# Altium schematic sheet int_driver.SchDoc
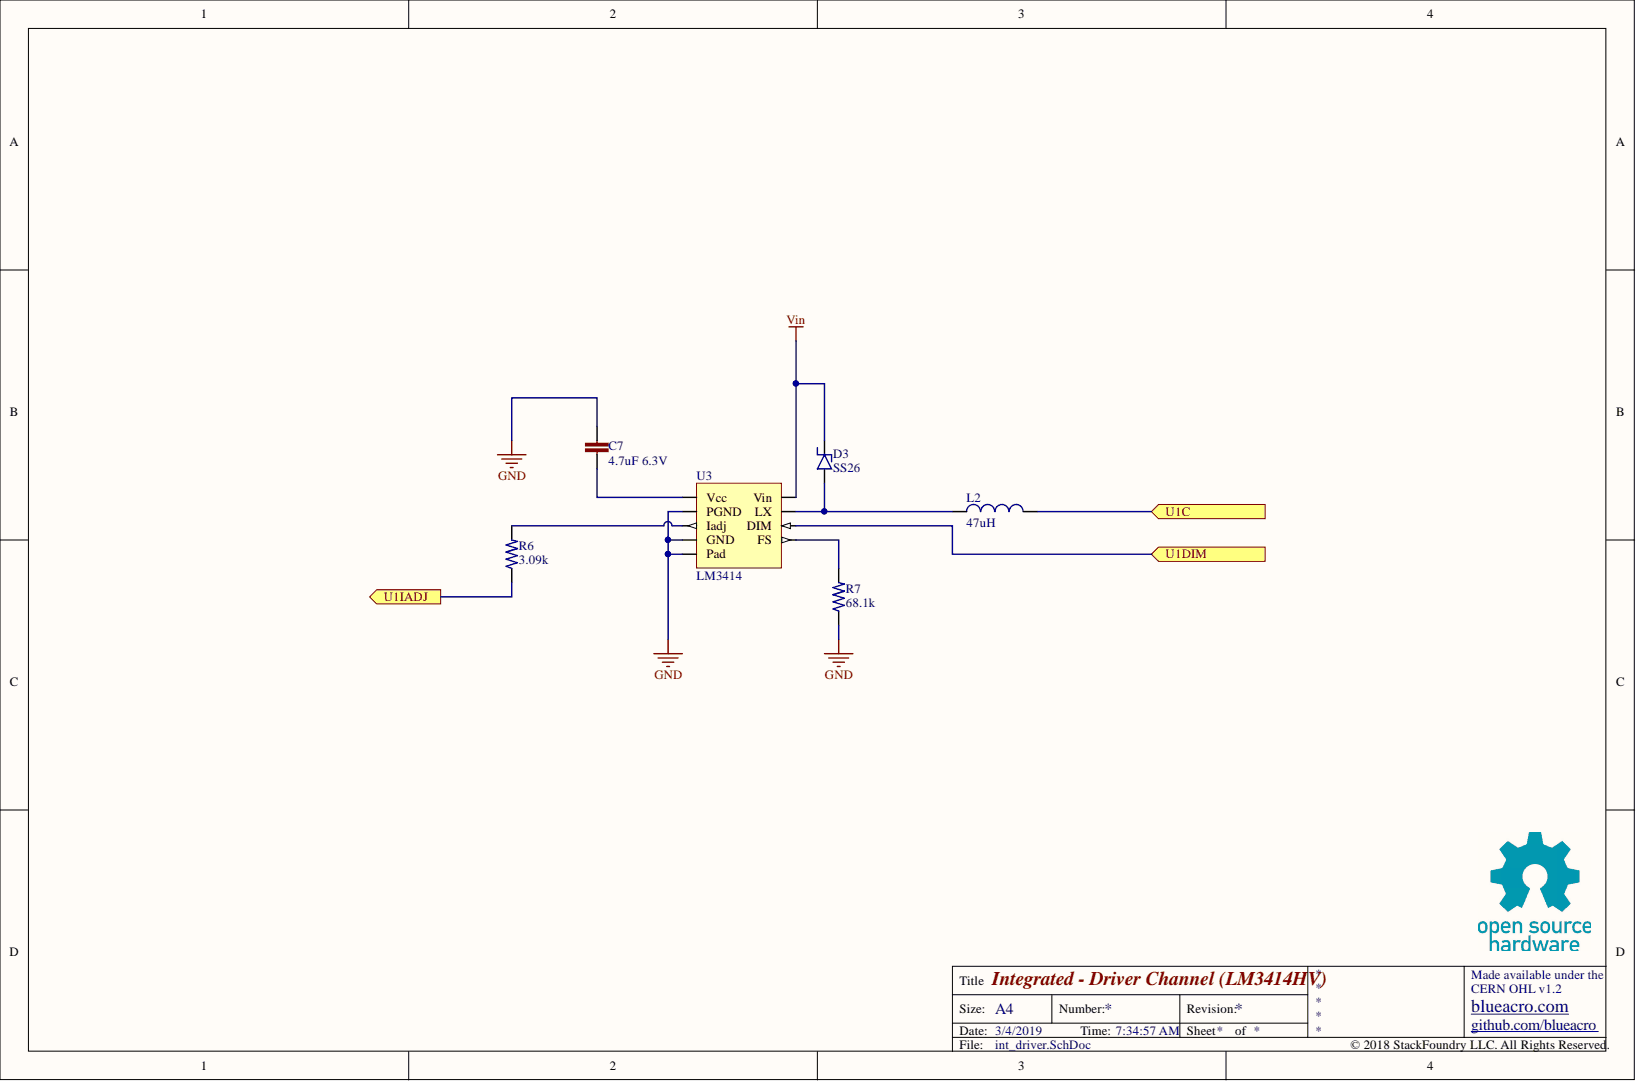
[diagram p0: int_driver.SchDoc]

## Parts
C7  4.7uF 6.3V  [C0603]
D3  SS26  [SMA]
L2  47uH  [Inductor_10mm]
R6  3.09k  [R0603]
R7  68.1k  [R0603]
U3  LM3414  [SO08 - PowerPad]

## Ports
U1C, U1DIM, U1IADJ

## Nets
GND: C7.2 R7.1 U3.2(PGND) U3.4(GND) U3.Pad
Vin: D3.K U3.8(Vin)
U1C: L2.2 ; port U1C
U1DIM: U3.6(DIM) ; port U1DIM
U1IADJ: R6.1 ; port U1IADJ
NetC7_1: C7.1 U3.1(Vcc)
NetD3_A: D3.A L2.1 U3.7(LX)
NetR6_2: R6.2 U3.3(Iadj)
NetR7_2: R7.2 U3.5(FS)

## Library data of the parts
C7 = C-EU: Component Kind: Standard; Library Name: rcl.IntLib
D3 = DIODE_SCHOTTKY: Component Kind: Standard; Library Name: general.IntLib
L2 = L-US: Component Kind: Standard; Library Name: rcl.IntLib
R6 R7 = R-US: Component Kind: Standard; Library Name: rcl.IntLib
U3 = LM3414: Component Kind: Standard; Library Name: texas.IntLib
